# Altium schematic sheet New Javanes Sheet1.SchDoc
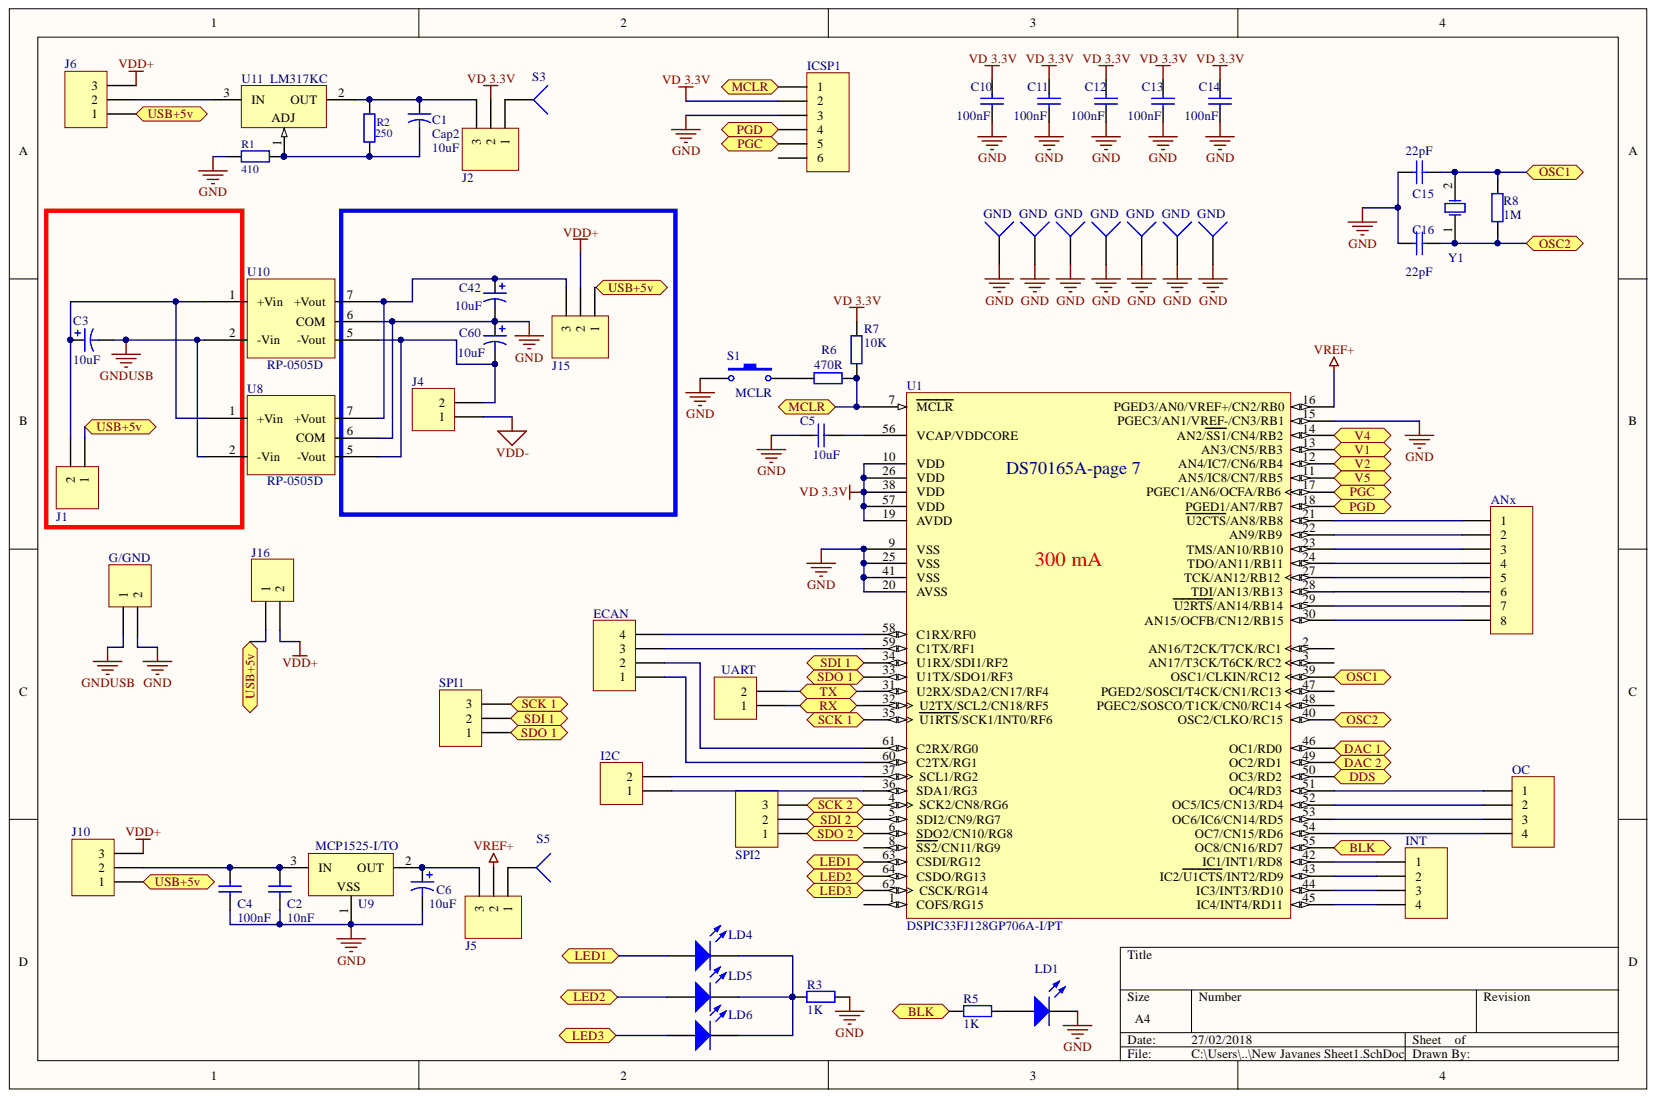
[diagram p0: New Javanes Sheet1.SchDoc]

## Parts
ANx  Header 8  [HDR1X8]  (value not drawn)
C1  Cap2  value 10uF  [B]
C2  value 10nF  [VP45-3.2]  (hidden comment: Cap)
C3  value 10uF  [B]  (hidden comment: Cap Pol1)
C4  value 100nF  [VP45-3.2]  (hidden comment: Cap)
C5  value 10uF  [VP45-3.2]  (hidden comment: Cap)
C6  value 10uF  [B]  (hidden comment: Cap Pol1)
C10  value 100nF  [VP45-3.2]  (hidden comment: Cap)
C11  value 100nF  [VP45-3.2]  (hidden comment: Cap)
C12  value 100nF  [VP45-3.2]  (hidden comment: Cap)
C13  value 100nF  [VP45-3.2]  (hidden comment: Cap)
C14  value 100nF  [VP45-3.2]  (hidden comment: Cap)
C15  value 22pF  [RAD-0.3]  (hidden comment: Cap)
C16  value 22pF  [RAD-0.3]  (hidden comment: Cap)
C42  value 10uF  [B]  (hidden comment: Cap Pol1)
C60  value 10uF  [B]  (hidden comment: Cap Pol1)
ECAN  Header 4  [HDR1X4]  (value not drawn)
G/GND  Header 2  [HDR1X2]  (value not drawn)
GND  Socket  [PIN1]  (value not drawn)
GND  Socket  [PIN1]  (value not drawn)
GND  Socket  [PIN1]  (value not drawn)
GND  Socket  [PIN1]  (value not drawn)
GND  Socket  [PIN1]  (value not drawn)
GND  Socket  [PIN1]  (value not drawn)
GND  Socket  [PIN1]  (value not drawn)
I2C  Header 2  [HDR1X2]  (value not drawn)
ICSP1  ICSP-U1  [HDR1X6]  (value not drawn)
INT  Header 4  [HDR1X4]  (value not drawn)
J1  Header 2  [HDR1X2]  (value not drawn)
J2  Header 3  [HDR1X3]  (value not drawn)
J4  Header 2  [HDR1X2]  (value not drawn)
J5  Header 3  [HDR1X3]  (value not drawn)
J6  Header 3  [HDR1X3]  (value not drawn)
J10  Header 3  [HDR1X3]  (value not drawn)
J15  Header 3  [HDR1X3]  (value not drawn)
J16  Header 2  [HDR1X2]  (value not drawn)
LD1  LED1  [LED-1]  (value not drawn)
LD4  LED1  [LED-1]  (value not drawn)
LD5  LED1  [LED-1]  (value not drawn)
LD6  LED1  [LED-1]  (value not drawn)
OC  Header 4  [HDR1X4]  (value not drawn)
R1  value 410  [AXIAL-0.3]  (hidden comment: Res2)
R2  value 250  [AXIAL-0.3]  (hidden comment: Res2)
R3  value 1K  [AXIAL-0.4]  (hidden comment: Res2)
R5  value 1K  [AXIAL-0.4]  (hidden comment: Res2)
R6  value 470R  [AXIAL-0.4]  (hidden comment: Res2)
R7  value 10K  [AXIAL-0.4]  (hidden comment: Res2)
R8  value 1M  [AXIAL-0.4]  (hidden comment: Res2)
S1  MCLR  [SPST-2]
S3  Socket  [PIN1]  (value not drawn)
S5  Socket  [PIN1]  (value not drawn)
SPI1  Header 3  [HDR1X3]  (value not drawn)
SPI2  Header 3  [HDR1X3]  (value not drawn)
U1  DSPIC33FJ128GP706A-I/PT  [TQFP-PT64_L]
U8  RP-0505D  [RACOM RP-0505 D]
U9  MCP1525-I/TO  [TO-92-TO3]
U10  RP-0505D  [RACOM RP-0505 D]
U11  LM317KC  [KC03]
UART  Header 2  [HDR1X2]  (value not drawn)
Y1  7,37MHz  [HC-49U]  (value not drawn)

## Ports
BLK, DAC 1, DAC 2, DDS, LED1, LED2, LED3, MCLR, OSC1, OSC2, PGC, PGD, RX, SCK 1, SCK 2, SDI 1, SDI 2, SDO 1, SDO 2, TX, USB+5v, V1, V2, V4, V5

## Nets
GND: C2.1 C4.1 C5.2 C6.2 C10.1 C11.1 C12.1 C13.1 C14.1 C15.1 C16.1 C42.2 C60.1 G/GND.2 GND.1 ICSP1.3 LD1.2 R1.2 R3.1 S1.1 U1.9(VSS) U1.15(PGEC3/AN1/VREF-/CN3/RB1) U1.20(AVSS) U1.25(VSS) U1.41(VSS) U8.6(COM) U9.1 U10.6(COM)
GNDUSB: C3.2 G/GND.1 U8.2(-Vin) U10.2(-Vin)
VD 3.3V: C10.2 C11.2 C12.2 C13.2 C14.2 ICSP1.2 J2.2 R7.2 U1.10(VDD) U1.19(AVDD) U1.26(VDD) U1.38(VDD) U1.57(VDD)
VDD+: J6.3 J10.3 J15.2 J16.2
VDD-: J4.1
VREF+: J5.2 U1.16(PGED3/AN0/VREF+/CN2/RB0)
BLK: R5.2 U1.55(OC8/CN16/RD7) ; port BLK
DAC 1: U1.46(OC1/RD0) ; port DAC 1
DAC 2: U1.49(OC2/RD1) ; port DAC 2
DDS: U1.50(OC3/RD2) ; port DDS
LED1: LD4.1 U1.63(CSDI/RG12) ; port LED1
LED2: LD5.1 U1.64(CSDO/RG13) ; port LED2
LED3: LD6.1 U1.62(CSCK/RG14) ; port LED3
MCLR: ICSP1.1 R6.1 R7.1 U1.7(MCLR) ; port MCLR
OSC1: C15.2 R8.1 U1.39(OSC1/CLKIN/RC12) Y1.2 ; port OSC1
OSC2: C16.2 R8.2 U1.40(OSC2/CLKO/RC15) Y1.1 ; port OSC2
PGC: ICSP1.5 U1.17(PGEC1/AN6/OCFA/RB6) ; port PGC
PGD: ICSP1.4 U1.18(PGED1/AN7/RB7) ; port PGD
RX: U1.32(U2TX/SCL2/CN18/RF5) UART.1 ; port RX
SCK 1: SPI1.3 U1.35(U1RTS/SCK1/INT0/RF6) ; port SCK 1
SCK 2: SPI2.3 U1.4(SCK2/CN8/RG6) ; port SCK 2
SDI 1: SPI1.2 U1.34(U1RX/SDI1/RF2) ; port SDI 1
SDI 2: SPI2.2 U1.5(SDI2/CN9/RG7) ; port SDI 2
SDO 1: SPI1.1 U1.33(U1TX/SDO1/RF3) ; port SDO 1
SDO 2: SPI2.1 U1.6(SDO2/CN10/RG8) ; port SDO 2
TX: U1.31(U2RX/SDA2/CN17/RF4) UART.2 ; port TX
USB+5v: J1.1 J6.1 J10.1 J15.1 J16.1 ; port USB+5v
V1: U1.13(AN3/CN5/RB3) ; port V1
V2: U1.12(AN4/IC7/CN6/RB4) ; port V2
V4: U1.14(AN2/SS1/CN4/RB2) ; port V4
V5: U1.11(AN5/IC8/CN7/RB5) ; port V5
NetANx_1: ANx.1 U1.21(U2CTS/AN8/RB8)
NetANx_2: ANx.2 U1.22(AN9/RB9)
NetANx_3: ANx.3 U1.23(TMS/AN10/RB10)
NetANx_4: ANx.4 U1.24(TDO/AN11/RB11)
NetANx_5: ANx.5 U1.27(TCK/AN12/RB12)
NetANx_6: ANx.6 U1.28(TDI/AN13/RB13)
NetANx_7: ANx.7 U1.29(U2RTS/AN14/RB14)
NetANx_8: ANx.8 U1.30(AN15/OCFB/CN12/RB15)
NetC1_1: C1.1 J2.3 R2.1 U11.2
NetC1_2: C1.2 R1.1 R2.2 U11.1
NetC2_2: C2.2 C4.2 J10.2 U9.3
NetC3_1: C3.1 J1.2 U8.1(+Vin) U10.1(+Vin)
NetC5_1: C5.1 U1.56(VCAP/VDDCORE)
NetC6_1: C6.1 J5.3 U9.2
NetC42_1: C42.1 J15.3 U8.7(+Vout) U10.7(+Vout)
NetC60_2: C60.2 J4.2 U8.5(-Vout) U10.5(-Vout)
NetECAN_1: ECAN.1 U1.60(C2TX/RG1)
NetECAN_2: ECAN.2 U1.61(C2RX/RG0)
NetECAN_3: ECAN.3 U1.59(C1TX/RF1)
NetECAN_4: ECAN.4 U1.58(C1RX/RF0)
NetI2C_1: I2C.1 U1.36(SDA1/RG3)
NetI2C_2: I2C.2 U1.37(SCL1/RG2)
NetINT_1: INT.1 U1.42(IC1/INT1/RD8)
NetINT_2: INT.2 U1.43(IC2/U1CTS/INT2/RD9)
NetINT_3: INT.3 U1.44(IC3/INT3/RD10)
NetINT_4: INT.4 U1.45(IC4/INT4/RD11)
NetJ2_1: J2.1 S3.1
NetJ5_1: J5.1 S5.1
NetJ6_2: J6.2 U11.3
NetLD1_1: LD1.1 R5.1
NetLD4_2: LD4.2 LD5.2 LD6.2 R3.2
NetOC_1: OC.1 U1.51(OC4/RD3)
NetOC_2: OC.2 U1.52(OC5/IC5/CN13/RD4)
NetOC_3: OC.3 U1.53(OC6/IC6/CN14/RD5)
NetOC_4: OC.4 U1.54(OC7/CN15/RD6)
NetR6_2: R6.2 S1.2

Not connected: ICSP1 6; U1 1(COFS/RG15) 2(AN16/T2CK/T7CK/RC1) 3(AN17/T3CK/T6CK/RC2) 8(SS2/CN11/RG9) 47(PGED2/SOSCI/T4CK/CN1/RC13) 48(PGEC2/SOSCO/T1CK/CN0/RC14)

## Library data of the parts
ANx = Header 8: Header, 8-Pin; Component Kind: Standard; LatestRevisionDate: 17-Jul-2002; LatestRevisionNote: Re-released for DXP Platform.; Library Name: Miscellaneous Connectors.IntLib; Signal Integrity: Connector; Publisher: Altium Limited
C1 = Cap2: Capacitor; Component Kind: Standard; Library Name: Miscellaneous Devices.IntLib; PackageReference: CAPR5-4X5; Published: 23-Sep-2002; Signal Integrity: Cap; Simulation: CAP; Publisher: Altium Limited
C2 C4 C5 C10-C16 = Cap: Capacitor; Component Kind: Standard; LatestRevisionDate: 17-Jul-2002; LatestRevisionNote: Re-released for DXP Platform.; Library Name: Miscellaneous Devices.IntLib; PackageReference: RAD-0.3; Published: 8-Jun-2000; Signal Integrity: Cap; Simulation: CAP; Publisher: Altium Limited
C3 C6 C42 C60 = Cap Pol1: Polarized Capacitor (Radial); Component Kind: Standard; LatestRevisionDate: 17-Jul-2002; LatestRevisionNote: Re-released for DXP Platform.; Library Name: Miscellaneous Devices.IntLib; PackageReference: RB7.6-15; Published: 8-Jun-2000; Signal Integrity: Cap Pol; Simulation: CAP; Publisher: Altium Limited
ECAN INT OC = Header 4: Header, 4-Pin; Component Kind: Standard; LatestRevisionDate: 17-Jul-2002; LatestRevisionNote: Re-released for DXP Platform.; Library Name: Miscellaneous Connectors.IntLib; Signal Integrity: Connector; Publisher: Altium Limited
G/GND I2C J1 J4 J16 UART = Header 2: Header, 2-Pin; Component Kind: Standard; LatestRevisionDate: 17-Jul-2002; LatestRevisionNote: Re-released for DXP Platform.; Library Name: Miscellaneous Connectors.IntLib; Signal Integrity: Connector; Publisher: Altium Limited
ICSP1 = Header 6: Header, 6-Pin; Component Kind: Standard; LatestRevisionDate: 17-Jul-2002; LatestRevisionNote: Re-released for DXP Platform.; Library Name: Miscellaneous Connectors.IntLib; Signal Integrity: Connector; Publisher: Altium Limited
J2 J5 J6 J10 J15 SPI1 SPI2 = Header 3: Header, 3-Pin; Component Kind: Standard; LatestRevisionDate: 17-Jul-2002; LatestRevisionNote: Re-released for DXP Platform.; Library Name: Miscellaneous Connectors.IntLib; Signal Integrity: Connector; Publisher: Altium Limited
LD1 LD4-LD6 = LED1: Typical RED GaAs LED; Component Kind: Standard; LatestRevisionDate: 08-Jul-2005; LatestRevisionNote: Mech Layer 1 removed.; Library Name: Miscellaneous Devices.IntLib; PackageDescription: LED; 2 Leads; PackageReference: LED-1; Published: 8-Jun-2000; Simulation: LED1; Publisher: Altium Limited
R1-R3 R5-R8 = Res2: Resistor; Component Kind: Standard; LatestRevisionDate: 17-Jul-2002; LatestRevisionNote: Re-released for DXP Platform.; Library Name: Miscellaneous Devices.IntLib; PackageReference: AXIAL-0.4; Published: 8-Jun-2000; Signal Integrity: Res; Simulation: RESISTOR; Publisher: Altium Limited
S1 = SW-PB: Switch; Component Kind: Standard; LatestRevisionDate: 17-Jul-2002; LatestRevisionNote: Re-released for DXP Platform.; Library Name: Miscellaneous Devices.IntLib; PackageReference: SPST-2; Published: 24-Mar-1999; Publisher: Altium Limited
U1 = DSPIC33FJ128GP706A-I/PT: High-Performance 16-bit Digital Signal Controller, 128 KB Flash, 16 KB RAM , 64-Pin TQFP, Industrial Temperature; Code_JEDEC: MS-026; Component Kind: Standard; ComponentLink1Description: Datasheet; ComponentLink1URL: http://ww1.microchip.com/downloads/en/DeviceDoc/70593B.pdf; ComponentLink2Description: Packaging Specification; ComponentLink2URL: http://www.microchip.com/stellent/groups/techpub_sg/documents/packagingspec/en012702.pdf; ComponentLink3Description: Microchip.com Home; ComponentLink3URL: http://www.microchip.com/; DatasheetVersion: revB, Apr-2009; Library Name: Microchip DSC 16-Bit dsPIC33F.IntLib; PackageDescription: 64-Lead Thin Plastic Quad Flat Pack (PT) - 10x10x1mm Body, 2.00mm [TQFP]; PackageReference: TQFP-PT64; PackageVersion: revBB, Aug-2009; Published: 2-Dec-2009; Supplier 1: Digi-Key; Supplier Part Number 1: DSPIC33FJ128GP706A-I/PT-ND; Publisher: Altium Limited
U8 U10 = RACOM RP-0505D: Component Kind: Standard; Description: RP-0505D; Library Name: Schlib-Igor-marques.SchLib
U9 = MCP1525-I/TO: 2.5V Voltage Reference, 3-Pin TO-92, Industrial Temperature; Component Kind: Standard; ComponentLink1Description: Manufacturer Link; ComponentLink1URL: http://www.microchip.com; ComponentLink2Description: Datasheet; ComponentLink2URL: http://ww1.microchip.com/downloads/en/DeviceDoc/21653b.pdf; ComponentLink3Description: Packaging Specification; ComponentLink3URL: http://ww1.microchip.com/downloads/en/PackagingSpec/00049AW.pdf; DatasheetVersion: revB, Feb-2005; PackageDescription: 3-Lead Plastic Transistor Outline (TO) [TO-92]; PackageReference: TO-92-TO3; PackageVersion: revAW, Oct-2008; Published: 29-Jan-2009; Library Name: Microchip Power Management Votage Reference.IntLib; Publisher: Altium Limited
U11 = LM317KC: 3-Terminal Adjustable Regulator; Note: Pin 2 is electrically connected to tab; Component Kind: Standard; ComponentLink1Description: Manufacturer Link; ComponentLink1URL: http://www.ti.com/; DatasheetVersion: Feb-1988; Library Name: TI Power Mgt Voltage Regulator.IntLib; PackageDescription: TO, Thru-Hole, Vertical, Heatsink Mounted; 3 In-Line Leads; Pitch 2.54 mm; PackageReference: KC03; PackageVersion: Sep-1995; Published: 15-Apr-2002; Publisher: Altium Limited
Y1 = ECS-10-13-1: Crystal Oscillator; Component Kind: Standard; ComponentLink1Description: Manufacturer Link; ComponentLink1URL: http://www.ecsxtal.com/; ComponentLink2Description: Datasheet; ComponentLink2URL: http://www.ecsxtal.com/pdf2/HC49U.PDF; DatasheetDocument: 18-Apr-2000; Library Name: ECS Crystal Oscillator.IntLib; PackageReference: HC-49U; Published: 17-Jul-2002; Publisher: Altium Limited
GND GND GND GND GND GND GND S3 S5 = Socket: Component Kind: Standard; Description: Socket; LatestRevisionDate: 17-Jul-2002; LatestRevisionNote: Re-released for DXP Platform.; Library Name: Miscellaneous Connectors.IntLib; Signal Integrity: Connector; Publisher: Altium Limited
